# Altium schematic sheet 05_POWER.SchDoc
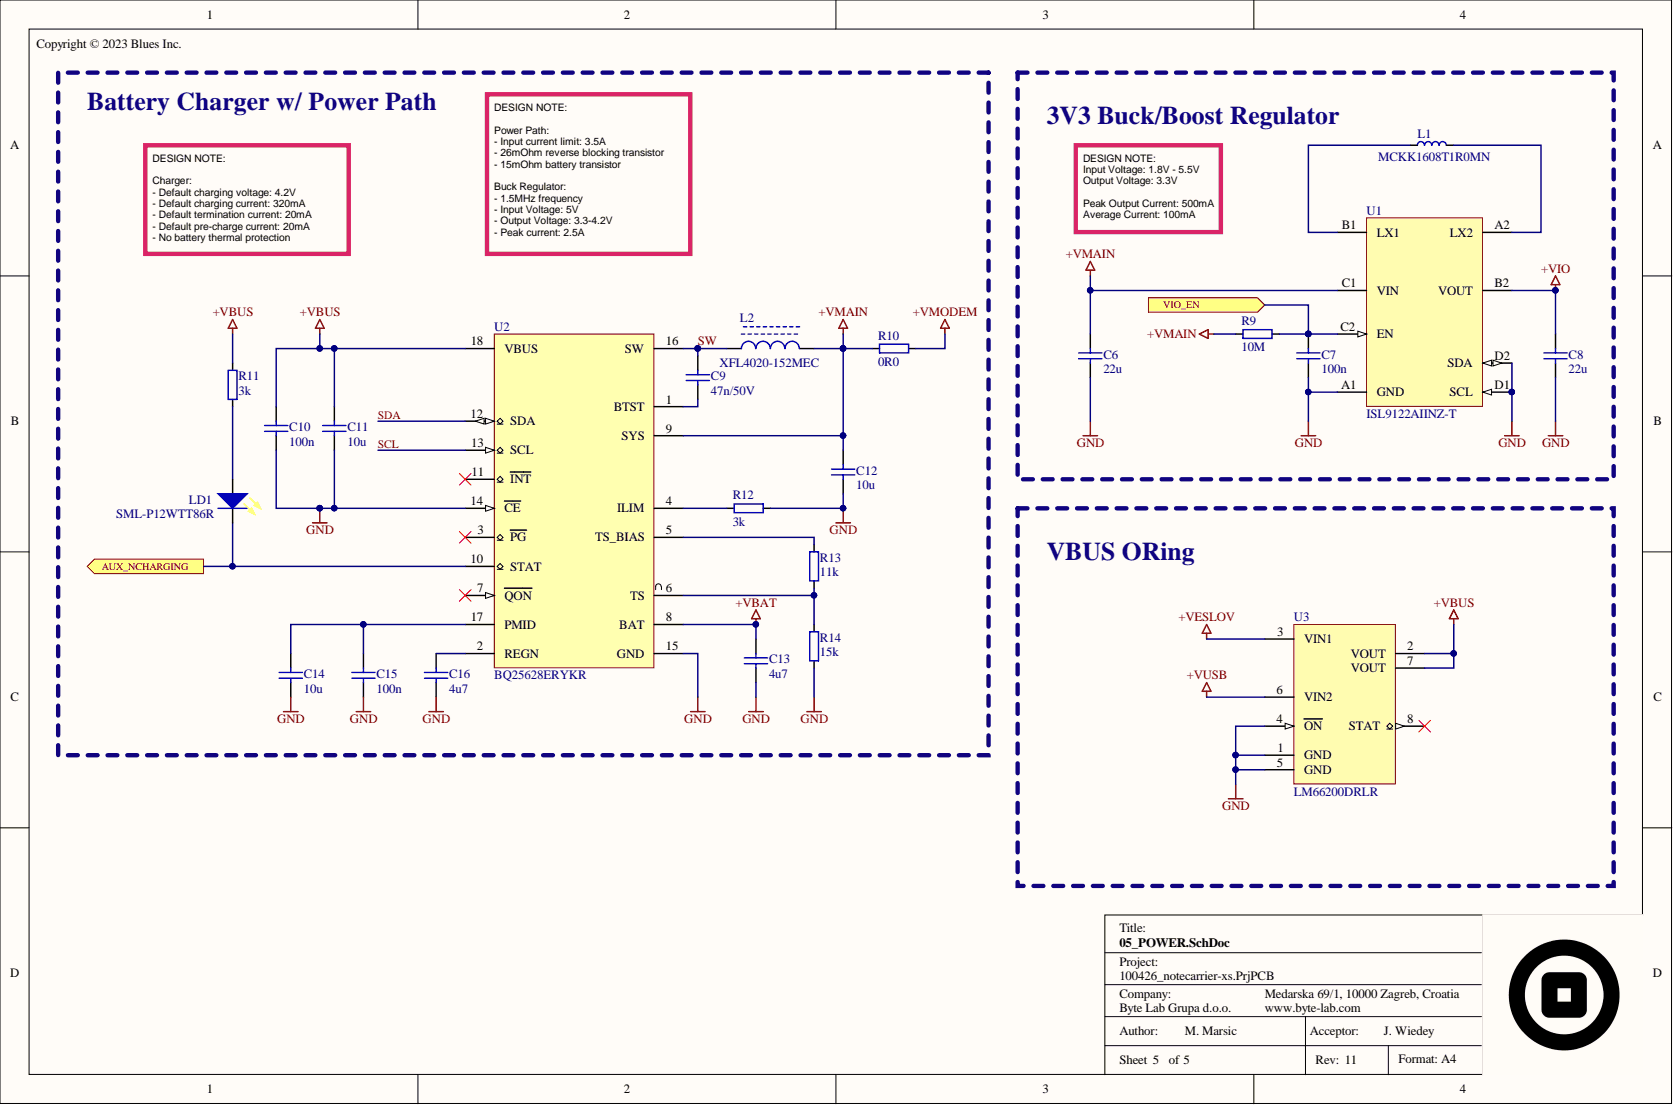
[diagram p0: 05_POWER.SchDoc]

## Parts
C6  22u  [C-0805]
C7  100n  [C-0402]
C8  22u  [C-0805]
C9  47n/50V  [C-0402]
C10  100n  [C-0402]
C11  10u  [C-1206]
C12  10u  [C-1206]
C13  4u7  [C-0603]
C14  10u  [C-0805]
C15  100n  [C-0402]
C16  4u7  [C-0603]
L1  MCKK1608T1R0MN  [L-0603]
L2  XFL4020-152MEC  [IND_XFL4020]
LD1  SML-P12WTT86R  [LED-0402]
R9  10M  [R-0402]
R10  0R0  [R-0603]
R11  3k  [R-0402]
R12  3k  [R-0402]
R13  11k  [R-0402]
R14  15k  [R-0402]
U1  ISL9122AIINZ-T  [BGA8N40P2X4_180x100x50]
U2  BQ25628ERYKR  [QFN40P300X150X80-18N]
U3  LM66200DRLR  [SOT-583]

## Ports
AUX_NCHARGING, VIO_EN

## Nets
+VBAT: C13.2 U2.8(BAT)
+VBUS: C10.1 C11.1 R11.2 U2.18(VBUS) U3.2(VOUT) U3.7(VOUT)
+VESLOV: U3.3(VIN1)
+VIO: C8.2 U1.B2(VOUT)
+VMAIN: C6.2 C12.1 L2.2 R9.1 R10.1 U1.C1(VIN) U2.9(SYS)
+VMODEM: R10.2
+VUSB: U3.6(VIN2)
GND: C6.1 C7.2 C8.1 C10.2 C11.2 C12.2 C13.1 C14.1 C15.1 C16.1 R12.1 R14.1 U1.A1(GND) U1.D1(SCL) U1.D2(SDA) U2.14(CE) U2.15(GND) U3.1(GND) U3.4(ON) U3.5(GND)
AUX_NCHARGING: LD1.2 U2.10(STAT) ; port AUX_NCHARGING
SCL: U2.13(SCL)
SDA: U2.12(SDA)
SW: C9.2 L2.1 U2.16(SW)
VIO_EN: C7.1 R9.2 U1.C2(EN) ; port VIO_EN
NetC9_1: C9.1 U2.1(BTST)
NetC14_2: C14.2 C15.2 U2.17(PMID)
NetC16_2: C16.2 U2.2(REGN)
NetL1_1: L1.1 U1.B1(LX1)
NetL1_2: L1.2 U1.A2(LX2)
NetLD1_1: LD1.1 R11.1
NetR12_2: R12.2 U2.4(ILIM)
NetR13_1: R13.1 U2.5(TS_BIAS)
NetR13_2: R13.2 R14.2 U2.6(TS)

Not connected: U2 3(PG) 7(QON) 11(INT); U3 8(STAT)

## Library data of the parts
C6 C8 = C0805-22u-16V-X5R: Capacitor ceramic 22u ±10% X5R 16V 0805; BL Part Number: 2006-222; Checked_By: Hamin; Drawn_By: Fumic; Component Kind: Standard; DateModified: 28-07-2022; Library Name: BL_Passive.SchLib; Manufacturer: N/A; Manufacturer Part Number: N/A; Simulation: CAP
C7 C10 = C0402-100n-16V-X7R: Capacitor ceramic 100n ±10% X7R 16V 0402; BL Part Number: 2000-908; Checked_By: Dodig; Drawn_By: Puskar; Component Kind: Standard; DateModified: 27-08-2013; Library Name: BL_Passive.SchLib; Manufacturer: N/A; Manufacturer Part Number: N/A; Simulation: CAP; Supplier 1: Digi-Key; Supplier Part Number 1: 490-3261-1-ND
C9 = C0402-47n-50V-X7R: Capacitor ceramic 47n 10% X7R 50V 0402; BL Part Number: 2003-965; Checked_By: Hamin; Drawn_By: Hlupic; Component Kind: Standard; DateModified: 08-05-2018; Library Name: BL_Passive.SchLib; Manufacturer: N/A; Manufacturer Part Number: N/A; Supplier 1: Digi-Key; Supplier Part Number 1: 311-3815-2-ND
C11 C12 = C1206-10u-16V-X7R: Capacitor ceramic 10u ±10% X7R 16V 1206; BL Part Number: 2000-447; Checked_By: Zvonc; Drawn_By: Dodig; Component Kind: Standard; DateModified: 04-07-2013; Library Name: BL_Passive.SchLib; Manufacturer: N/A; Manufacturer Part Number: N/A; Simulation: CAP; Supplier 1: Digi-Key; Supplier Part Number 1: 1276-1137-1-ND
C13 C16 = C0603-4u7-10V-X5R: Capacitor ceramic 4u7 ±10% X5R 10V 0603; BL Part Number: 2003-721; Checked_By: Marsic; Drawn_By: Hamin; Component Kind: Standard; DateModified: 19-06-2023; Library Name: BL_Passive.SchLib; Manufacturer: N/A; Manufacturer Part Number: N/A
C14 = C0805-10u-25V-X5R: Capacitor ceramic 10u ±20% X5R 25V 0805; BL Part Number: 2004-293; Manufacturer: Murata Electronics; Manufacturer Part Number: GRM21BR61E106MA73L; Checked_By: Hamin; Drawn_By: Hlupic; Component Kind: Standard; DateModified: 23-01-2019; Library Name: BL_Passive.SchLib; Supplier 1: Digi-Key; Supplier Part Number 1: 490-10748-1-ND
C15 = C0402-100n-25V-X5R: Capacitor ceramic 100n ±10% X5R 25V 0402; BL Part Number: 2004-296; Checked_By: Hamin; Drawn_By: PuskarJ; Component Kind: Standard; DateModified: 24-01-2019; Library Name: BL_Passive.SchLib; Manufacturer: N/A; Manufacturer Part Number: N/A; Simulation: CAP; Supplier 1: Digi-Key; Supplier Part Number 1: 1276-1043-1-ND
L1 = L0603-1u-20 MCKK1608T1R0MN: Inductor 0603 1u ±20% 1.3A; BL Part Number: 2003-989; Manufacturer: Taiyo Yuden; Checked_By: Hlupic; Drawn_By: Fumic; Component Kind: Standard; DateModified: 30-01-2020; Library Name: BL_Passive.SchLib; Manufacturer Part Number: MCKK1608T1R0MN; Supplier 1: Digi-Key; Supplier Part Number 1: 587-5973-1-ND
L2 = Inductor XFL4020-152MEC: Inductor 1u5 ±20% 4.6A SMD; BL Part Number: 2006-846; Manufacturer: Coilcraft; Checked_By: Hlupic; Drawn_By: Marsic; Component Kind: Standard; DateModified: 29-09-2022; Library Name: BL_Passive.SchLib; Manufacturer Part Number: XFL4020-152MEC
LD1 = LED_SML-P12WTT86R: LED YELLOW CLEAR 0402 SMD; BL Part Number: 2007-660; Manufacturer: ROHM Semiconductor; Checked_By: Hamin; Component Kind: Standard; DateModified: 19-06-23; Library Name: BL_Active.SchLib; Manufacturer Part Number: SML-P12WTT86R; Drawn_By: Hamin
R9 = R0402-10M-1: Resistor 10M ±1% 0402; BL Part Number: 2002-832; Checked_By: Dodig; Drawn_By: Zvonc; Component Kind: Standard; DateModified: 24-05-2016; Library Name: BL_Passive.SchLib; Manufacturer: N/A; Manufacturer Part Number: N/A; Supplier 1: Digi-Key; Supplier Part Number 1: RC0402FR-0710ML
R10 = R0603-0R0: Resistor 0R0 0603; BL Part Number: 2000-723; Checked_By: Puskar; Drawn_By: Dodig; Component Kind: Standard; DateModified: 07-12-2013; Library Name: BL_Passive.SchLib; Manufacturer: N/A; Manufacturer Part Number: N/A; Supplier 1: Digi-Key; Supplier Part Number 1: 311-0.0GRCT-ND
R11 R12 = R0402-3k-1: Resistor 3k ±1% 0402; BL Part Number: 2001-570; Checked_By: Hamin; Drawn_By: Fumic; Component Kind: Standard; DateModified: 06-09-2021; Library Name: BL_Passive.SchLib; Manufacturer: N/A; Manufacturer Part Number: N/A
R13 = R0402-11k-1: Resistor 11k ±1% 0402; BL Part Number: 2004-237; Checked_By: Marsic; Drawn_By: PuskarJ; Component Kind: Standard; DateModified: 18-12-2018; Library Name: BL_Passive.SchLib; Manufacturer: N/A; Manufacturer Part Number: N/A
R14 = R0402-15k-1: Resistor 15k ±1% 0402; BL Part Number: 2001-157; Checked_By: Zvonc; Drawn_By: Puskar; Component Kind: Standard; DateModified: 23-01-2015; Library Name: BL_Passive.SchLib; Manufacturer: N/A; Manufacturer Part Number: N/A; Supplier 1: Digi-Key; Supplier Part Number 1: P15.0KLCT-ND
U1 = ISL9122AIINZ-T: IC CON BUCK-BOOST ADJ 0.5A WLCSP-8; BL Part Number: 2007-147; Manufacturer: Renesas; Checked_By: Hlupic; Drawn_By: Marsic; Component Kind: Standard; DateModified: 13-01-2023; Library Name: BL_Analog.SchLib; Manufacturer Part Number: ISL9122AIINZ-T
U2 = BQ25628ERYKR: IC LI-ION CHARGE MGMT 16-QFN; BL Part Number: 2008-206; Manufacturer: Texas Instruments; Drawn_By: Marsic; Component Kind: Standard; DateModified: 17-01-2024; Library Name: BL_Analog.SchLib; Manufacturer Part Number: BQ25628ERYKR; Supplier 1: Digi-Key; Supplier Part Number 1: 296-BQ25628ERYKRCT-ND - Cut Tape (CT)
U3 = LM66200DRLR: IC, Power switch, OR Controller N+1 ORing Controller N-Channel 2:1 SOT-583; BL Part Number: 2007-318; Manufacturer: Texas Instruments; Checked_By: Hamin; Drawn_By: Golubic; Component Kind: Standard; DateModified: 06-02-2023; Library Name: BL_Analog.SchLib; Manufacturer Part Number: LM66200DRLR; Supplier 1: Digi-Key; Supplier Part Number 1: 296-LM66200DRLRTR-ND
